# Altium schematic sheet 00_POWER.SchDoc(00_POWER)
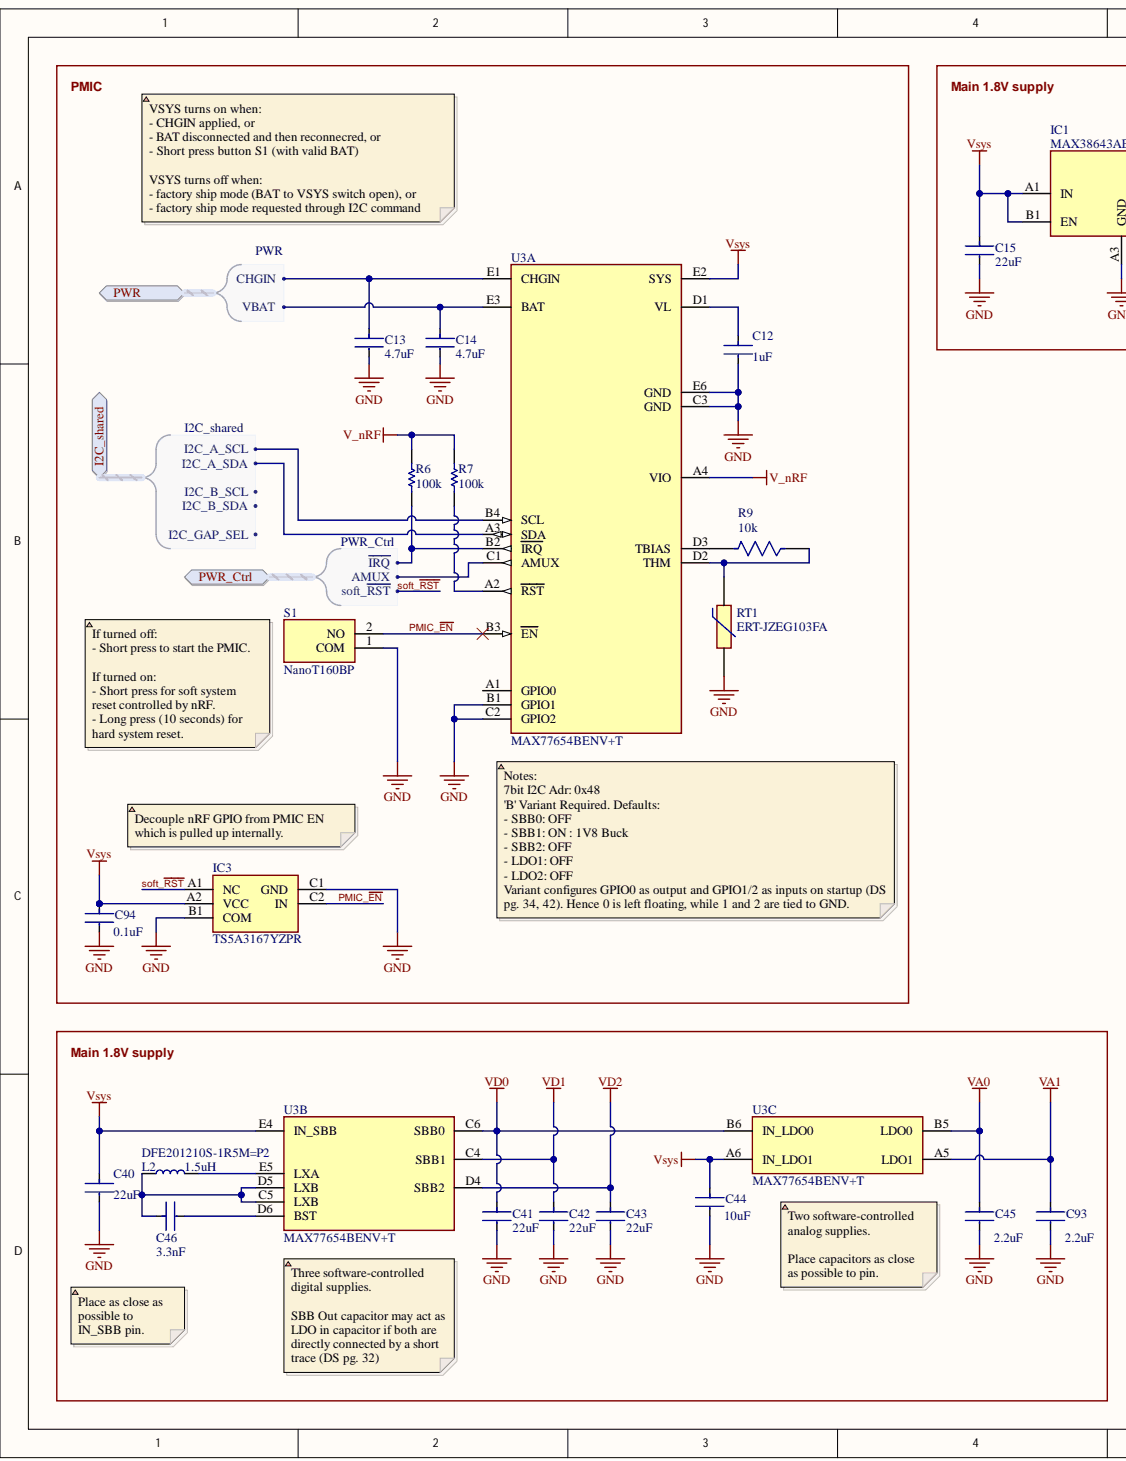
[diagram p0: 00_POWER.SchDoc, left]
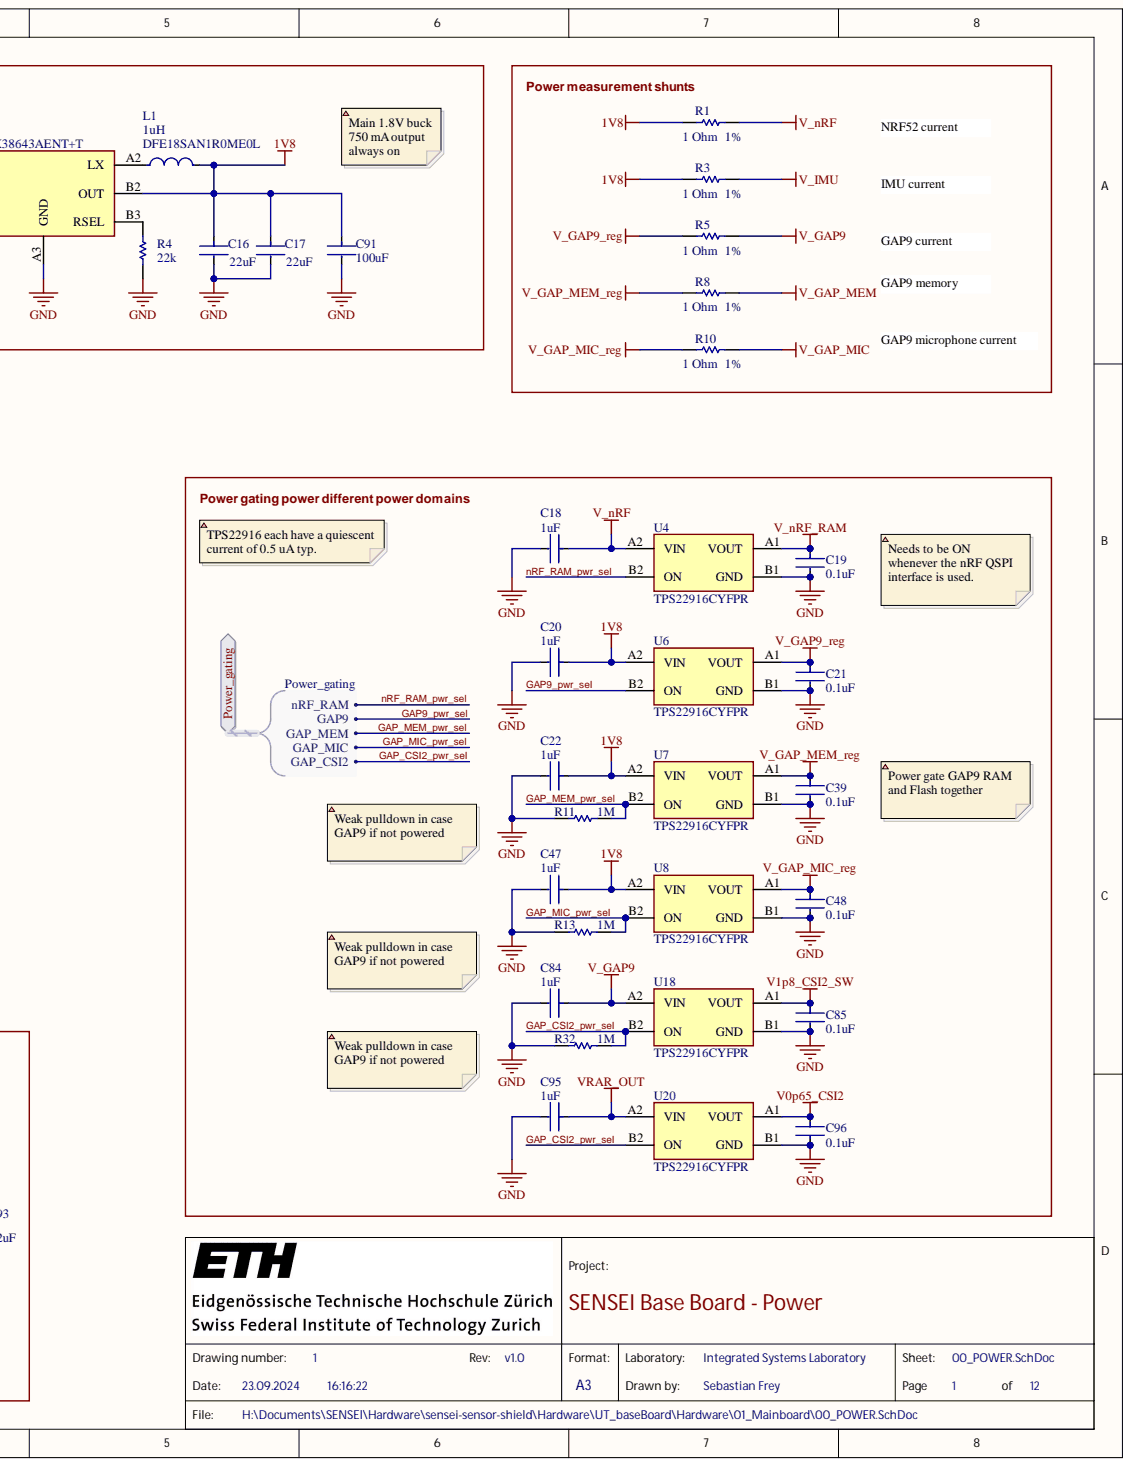
[diagram p1: 00_POWER.SchDoc, right]

## Parts
C12  Capacitance: 1uF  [FP-CL03-IPC_B]  (hidden comment: CL03A105KP3NSNC)
C13  Capacitance: 4.7uF  [FP-C1005-050-0_15-IPC_C]  (hidden comment: C1005X5R0J475K050BC)
C14  Capacitance: 4.7uF  [FP-C1005-050-0_15-IPC_C]  (hidden comment: C1005X5R0J475K050BC)
C15  Capacitance: 22uF  [FP-CL746-IPC_C]  (hidden comment: CL05A226MQ5QUNC)
C16  Capacitance: 22uF  [FP-CL746-IPC_C]  (hidden comment: CL05A226MQ5QUNC)
C17  Capacitance: 22uF  [FP-CL746-IPC_C]  (hidden comment: CL05A226MQ5QUNC)
C18  Capacitance: 1uF  [FP-CL03-IPC_B]  (hidden comment: CL03A105KP3NSNC)
C19  Capacitance: 0.1uF  [FP-GRM033-0_03-IPC_B]  (hidden comment: GRM033Z71C104KE14D)
C20  Capacitance: 1uF  [FP-CL03-IPC_B]  (hidden comment: CL03A105KP3NSNC)
C21  Capacitance: 0.1uF  [FP-GRM033-0_03-IPC_B]  (hidden comment: GRM033Z71C104KE14D)
C22  Capacitance: 1uF  [FP-CL03-IPC_B]  (hidden comment: CL03A105KP3NSNC)
C39  Capacitance: 0.1uF  [FP-GRM033-0_03-IPC_B]  (hidden comment: GRM033Z71C104KE14D)
C40  Capacitance: 22uF  [FP-CL746-IPC_C]  (hidden comment: CL05A226MQ5QUNC)
C41  Capacitance: 22uF  [FP-CL746-IPC_C]  (hidden comment: CL05A226MQ5QUNC)
C42  Capacitance: 22uF  [FP-CL746-IPC_C]  (hidden comment: CL05A226MQ5QUNC)
C43  Capacitance: 22uF  [FP-CL746-IPC_C]  (hidden comment: CL05A226MQ5QUNC)
C44  value 10uF  Capacitance: 10uF  [FP-CL05A106MP5NUNC-IPC_C]  (hidden comment: CL05A106MP5NUNC)
C45  Capacitance: 2.2uF  [FP-GRM155-0_2-IPC_C]  (hidden comment: GRM155C70J225KE11D)
C46  Capacitance: 3.3nF  [FP-GRM033-0_03-IPC_A]  (hidden comment: GRM033R71E332KA12D)
C47  Capacitance: 1uF  [FP-CL03-IPC_B]  (hidden comment: CL03A105KP3NSNC)
C48  Capacitance: 0.1uF  [FP-GRM033-0_03-IPC_B]  (hidden comment: GRM033Z71C104KE14D)
C84  Capacitance: 1uF  [FP-CL03-IPC_B]  (hidden comment: CL03A105KP3NSNC)
C85  Capacitance: 0.1uF  [FP-GRM033-0_03-IPC_B]  (hidden comment: GRM033Z71C104KE14D)
C91  Capacitance: 100uF  [FP-GRM21B-0_2-e0_2_0_7-MFG]  (hidden comment: GRM21BR60G107ME11L)
C93  Capacitance: 2.2uF  [FP-GRM155-0_2-IPC_C]  (hidden comment: GRM155C70J225KE11D)
C94  Capacitance: 0.1uF  [FP-GRM033-0_03-IPC_B]  (hidden comment: GRM033Z71C104KE14D)
C95  Capacitance: 1uF  [FP-CL03-IPC_B]  (hidden comment: CL03A105KP3NSNC)
C96  Capacitance: 0.1uF  [FP-GRM033-0_03-IPC_B]  (hidden comment: GRM033Z71C104KE14D)
IC1  MAX38643AENT+T  [BGA6C40P3X2_142X89X50]
IC3  TS5A3167YZPR  [BGA5C50P2X3_89X139X50]
L1  DFE18SAN1R0ME0L  Inductance: 1uH  [FP-DFE18SAN_E0-MFG]
L2  DFE201210S-1R5M=P2  Inductance: 1.5uH  [IND_0805_DFE201210S]
R1  value 1 Ohm  Tolerance: 1%  [RESC1005X40X25LL05T10]  (hidden comment: CRCW04021R00FKEDHP)
R3  value 1 Ohm  Tolerance: 1%  [RESC1005X40X25LL05T10]  (hidden comment: CRCW04021R00FKEDHP)
R4  Resistance: 22k  [RESC0603X26X15LL03T05]  (hidden comment: RK73H1HTTC2202F)
R5  value 1 Ohm  Tolerance: 1%  [RESC1005X40X25LL05T10]  (hidden comment: CRCW04021R00FKEDHP)
R6  value 100k  [RESC0201(0603)_L]  (hidden comment: RC0201FR-07100KL)
R7  value 100k  [RESC0201(0603)_L]  (hidden comment: RC0201FR-07100KL)
R8  value 1 Ohm  Tolerance: 1%  [RESC1005X40X25LL05T10]  (hidden comment: CRCW04021R00FKEDHP)
R9  Resistance: 10k  [FP-RMCF0201-IPC_C]  (hidden comment: RMCF0201FT10K0)
R10  value 1 Ohm  Tolerance: 1%  [RESC1005X40X25LL05T10]  (hidden comment: CRCW04021R00FKEDHP)
R11  value 1M  [RESC0201(0603)_L]  (hidden comment: ERJ-1GNJ105C)
R13  value 1M  [RESC0201(0603)_L]  (hidden comment: ERJ-1GNJ105C)
R32  value 1M  [RESC0201(0603)_L]  (hidden comment: ERJ-1GNJ105C)
RT1  ERT-JZEG103FA  [ERTJZEG103FA]
S1  NanoT160BP  [NanoT160BP]
U3  MAX77654BENV+T  [WLP_30_MAX77654]  (parts A,B,C)
U4  TPS22916CYFPR  [BGA4C40P2X2_78X78X50]
U6  TPS22916CYFPR  [BGA4C40P2X2_78X78X50]
U7  TPS22916CYFPR  [BGA4C40P2X2_78X78X50]
U8  TPS22916CYFPR  [BGA4C40P2X2_78X78X50]
U18  TPS22916CYFPR  [BGA4C40P2X2_78X78X50]
U20  TPS22916CYFPR  [BGA4C40P2X2_78X78X50]

## Ports
I2C_shared, I2C_shared.I2C_A_SCL, I2C_shared.I2C_A_SDA, I2C_shared.I2C_B_SCL, I2C_shared.I2C_B_SDA, I2C_shared.I2C_GAP_SEL, Power_gating, Power_gating.GAP9, Power_gating.GAP_CSI2, Power_gating.GAP_MEM, Power_gating.GAP_MIC, Power_gating.nRF_RAM, PWR, PWR.CHGIN, PWR.VBAT, PWR_Ctrl, PWR_Ctrl.AMUX, PWR_Ctrl.soft_~{RST}, PWR_Ctrl.~{IRQ}

## Nets
1V8: C16.1 C17.1 C20.1 C22.1 C47.1 C91.1 IC1.B2(OUT) L1.2 R1.1 R3.1 U6.A2(VIN) U7.A2(VIN) U8.A2(VIN)
GND: C12.2 C13.2 C14.2 C15.2 C16.2 C17.2 C18.2 C19.2 C20.2 C21.2 C22.2 C39.2 C40.2 C41.2 C42.2 C43.2 C44.2 C45.2 C47.2 C48.2 C84.2 C85.2 C91.2 C93.2 C94.2 C95.2 C96.2 IC1.A3(GND) IC3.B1(COM) IC3.C1(GND) R4.1 R11.1 R13.1 R32.1 RT1.2 S1.1 U3.B1(GPIO1) U3.C2(GPIO2) U3.C3(GND) U3.E6(GND) U4.B1(GND) U6.B1(GND) U7.B1(GND) U8.B1(GND) U18.B1(GND) U20.B1(GND)
V0p65_CSI2: C96.1 U20.A1(VOUT)
V1p8_CSI2_SW: C85.1 U18.A1(VOUT)
V_GAP9: C84.1 R5.2 U18.A2(VIN)
V_GAP9_reg: C21.1 R5.1 U6.A1(VOUT)
V_GAP_MEM: R8.2
V_GAP_MEM_reg: C39.1 R8.1 U7.A1(VOUT)
V_GAP_MIC: R10.2
V_GAP_MIC_reg: C48.1 R10.1 U8.A1(VOUT)
V_IMU: R3.2
V_nRF: C18.1 R1.2 R6.2 R7.2 U3.A4(VIO) U4.A2(VIN)
V_nRF_RAM: C19.1 U4.A1(VOUT)
VA0: C45.1 U3.B5(LDO0)
VA1: C93.1 U3.A5(LDO1)
VD0: C41.1 U3.B6(IN_LDO0) U3.C6(SBB0)
VD1: C42.1 U3.C4(SBB1)
VD2: C43.1 U3.D4(SBB2)
VRAR_OUT: C95.1 U20.A2(VIN)
Vsys: C15.1 C40.1 C44.1 C94.1 IC1.A1(IN) IC1.B1(EN) IC3.A2(VCC) U3.A6(IN_LDO1) U3.E2(SYS) U3.E4(IN_SBB)
GAP9_pwr_sel: U6.B2(ON) ; port Power_gating
GAP_CSI2_pwr_sel: R32.2 U18.B2(ON) U20.B2(ON) ; port Power_gating
GAP_MEM_pwr_sel: R11.2 U7.B2(ON) ; port Power_gating
GAP_MIC_pwr_sel: R13.2 U8.B2(ON) ; port Power_gating
nRF_RAM_pwr_sel: U4.B2(ON) ; port Power_gating
PMIC_~{EN}: IC3.C2(IN) S1.2 U3.B3(EN)
soft_~{RST}: IC3.A1(NC) ; port PWR_Ctrl
NetC12_1: C12.1 U3.D1(VL)
NetC13_1: C13.1 U3.E1(CHGIN) ; port PWR
NetC14_1: C14.1 U3.E3(BAT) ; port PWR
NetC46_1: C46.1 L2.1 U3.C5(LXB) U3.D5(LXB)
NetC46_2: C46.2 U3.D6(BST)
NetIC1_A2: IC1.A2(LX) L1.1
NetIC1_B3: IC1.B3(RSEL) R4.2
NetL2_2: L2.2 U3.E5(LXA)
NetR6_1: R6.1 U3.B2(IRQ) ; port PWR_Ctrl
NetR7_1: R7.1 U3.A2(RST)
NetR9_1: R9.1 RT1.1 U3.D2(THM)
NetR9_2: R9.2 U3.D3(TBIAS)
NetU3_A3: U3.A3(SDA) ; port I2C_shared
NetU3_B4: U3.B4(SCL) ; port I2C_shared
NetU3_C1: U3.C1(AMUX) ; port PWR_Ctrl
N00001: ; port I2C_shared
N00003: ; port I2C_shared
N00005: ; port I2C_shared

Not connected: U3 A1(GPIO0)

## Library data of the parts
C12 C18 C20 C22 C47 C84 C95 = CMP-13271-003594-1: Cap Ceramic 1uF 10V X5R ±10% Pad SMD 0201 +85°C T/R; Case Code (Imperial): 0201; Case Code (Metric): 0603; Case/Package: 0201; Component Kind: Standard; Length: 0.024inch; Library Name: Altium Content Vault; Max Operating Temperature: 85°C; Min Operating Temperature: -55°C; Mount: Surface Mount; Packaging: Cut Tape; Thickness: 0.013inch; Tolerance: 10%; Voltage Rating: 10V; Width: 0.012inch
C13 C14 = CMP-2000-05437-2: CAP CER 4.7UF 6.3V X5R 0402; Dielectric Material: Ceramic; Tolerance: 10%; Case/Package: 0402; Component Kind: Standard; Depth: 0.5mm; Dielectric: X5R; Height: 0.5mm; Lead Free: Lead Free; Length: 1mm; Library Name: Altium Content Vault; Max Operating Temperature: 85°C; Min Operating Temperature: -55°C; Mount: Surface Mount; RoHS Compliant: Yes; Series: C; Termination: SMD/SMT; Voltage: 6.3V
C15-C17 C40-C43 = CMP-13271-000286-1: Cap Ceramic 22uF 6.3V X5R ±20% SMD 0402 +85°C Paper T/R; Case Code (Imperial): 0402; Case Code (Metric): 1005; Case/Package: 0402; Component Kind: Standard; Length: 0.039inch; Library Name: Altium Content Vault; Max Operating Temperature: 85°C; Min Operating Temperature: -55°C; Mount: Surface Mount; Packaging: Tape and Reel; Thickness: 0.022inch; Tolerance: 20%; Voltage Rating: 6.3V; Width: 0.02inch
C19 C21 C39 C48 C85 C94 C96 = CMP-06035-035508-1: Chip Multilayer Ceramic Capacitors for General Purpose, 0201, 0.10uF, X7R, 15%, 10%, 16V; Case/Package: 0201; Component Kind: Standard; Library Name: Altium Content Vault
C44 = CMP-13271-000002-1: CAP CER 10UF 10V X5R 0402; Manufacturer: Samsung; Material: Ceramic; Temperature Coefficient: 15%; Tolerance: 20%; Automotive: No; Category: Cap; Component Kind: Standard; ComponentLink2Description: Datasheet; ComponentLink2URL: http://www.samsungsem.com/kr/support/product-search/mlcc/__icsFiles/afieldfile/2019/05/10/19-CL05A106MP5NUNC.pdf; Device Class L1: Passive Components; Device Class L2: Capacitors; Device Class L3: Ceramic Capacitors; Digikey Description: CAP CER 10UF 10V X5R 0402; Height: 0.7mm; Ipc Land Pattern Name: CAPC10050X50; Lead Free: Yes; Library Name: Altium Content Vault; Manufacturer Part Number: CL05A106MP5NUNC; Octopart: https://octopart.com/cl05a106mp5nunc-samsung-22457395; Package: CL05A106MP5NUNC; RoHS: TRUE; Temperature: -55°C to +85°C; Temperature Characteristic: X5R; Temperature Range High: +85°C; Temperature Range Low: -55°C; Voltage Rating: 10V
C45 C93 = CMP-06035-014902-1: Chip Multilayer Ceramic Capacitors for General Purpose, 0402, 2.2uF, X7S, 22%, 10%, 6.3V; Case Code (Imperial): 0402; Case Code (Metric): 1005; Case/Package: 0402; Component Kind: Standard; Dielectric: X7S; Height: 0.5mm; Length: 0.039inch; Library Name: Altium Content Vault; Max Operating Temperature: 125°C; Min Operating Temperature: -55°C; Mount: Surface Mount; Package Quantity: 10000; Packaging: Tape and Reel; Resistor Type: Ceramic; Termination: SMD/SMT; Thickness: 0.022inch; Tolerance: 10%; Voltage Rating: 6.3V; Voltage Rating (DC): 6.3V; Weight: 0.000212oz; Width: 0.02inch
C46 = CMP-06035-035563-1: Chip Multilayer Ceramic Capacitors for General Purpose, 0201, 3300pF, X7R, 15%, 10%, 25V; Case Code (Imperial): 0201; Case Code (Metric): 0603; Case/Package: 0201; Component Kind: Standard; Dielectric: X7R; Height: 0.3mm; Length: 0.024inch; Library Name: Altium Content Vault; Max Operating Temperature: 125°C; Min Operating Temperature: -55°C; Mount: Surface Mount; Package Quantity: 15000; Packaging: Tape and Reel; Resistor Type: Ceramic; Termination: SMD/SMT; Thickness: 0.013inch; Tolerance: 10%; Voltage Rating: 25V; Voltage Rating (DC): 25V; Weight: 1.2E-05oz; Width: 0.012inch
C91 = CMP-06035-033356-1: Chip Multilayer Ceramic Capacitors for General Purpose, 0805, 100uF, X5R, 15%, 20%, 4V; Case Code (Imperial): 0805; Case Code (Metric): 2012; Case/Package: 0805; Component Kind: Standard; Dielectric: X5R; Length: 0.079inch; Library Name: Altium Content Vault; Max Operating Temperature: 85°C; Min Operating Temperature: -55°C; Mount: Surface Mount; Packaging: Tape and Reel; Thickness: 0.057inch; Tolerance: 20%; Voltage Rating: 4V; Voltage Rating (DC): 4V; Width: 0.049inch
IC1 = MAX38643AENT+: Integrated Circuit; Manufacturer_Name: Analog Devices; Component Kind: Standard; Datasheet Link: https://www.mouser.com/datasheet/2/256/MAX38640A-MAX38643B-1516623.pdf; Height: 0.5mm; Library Name: SamacSys.SchLib; Manufacturer_Part_Number: MAX38643AENT+; Mouser Part Number: 700-MAX38643AENT+; Mouser Price/Stock: https://www.mouser.co.uk/ProductDetail/Maxim-Integrated/MAX38643AENT%2b?qs=PzGy0jfpSMssjCGgbzOt4w%3D%3D
IC3 = TS5A3167YZPR: Integrated Circuit; Manufacturer_Name: Texas Instruments; Arrow Part Number: TS5A3167YZPR; Arrow Price/Stock: https://www.arrow.com/en/products/ts5a3167yzpr/texas-instruments; Component Kind: Standard; Datasheet Link: http://www.ti.com/lit/gpn/ts5a3167; Height: 0.5mm; Manufacturer_Part_Number: TS5A3167YZPR; Mouser Part Number: 595-TS5A3167YZPR; Mouser Price/Stock: https://www.mouser.co.uk/ProductDetail/Texas-Instruments/TS5A3167YZPR/?qs=0O%2FZFlpUpJV2gM0iE3NeCQ%3D%3D
L1 = CMP-06042-016331-1: FIXED IND 1UH 1.6A 144 MOHM SMD; Core Material: Metal; DC Resistance (DCR): 144mR; Saturation Current: 2A; Shielding: Shielded; Test Frequency: 1MHz; Tolerance: 20%; Case Code (Imperial): 0603; Case Code (Metric): 1608; Case/Package: 0603; Component Kind: Standard; Current Rating: 1.6A; Library Name: Altium Content Vault; Max Operating Temperature: 85°C; Min Operating Temperature: -40°C; Mount: Surface Mount; Packaging: Cut Tape; RoHS Compliant: Yes
L2 = L_0805_1.5uH_2A: L 1.5uH 2A 0805; Manufacturer: Murata; Component Kind: Standard; Library Name: EEG_SchLib.SchLib; Manufacturer Part Number: DFE201210S-1R5M=P2; Supplier 1: Mouser; Supplier Part Number 1: 81-DFE201210S-1R5MP2
R1 R3 R5 R8 R10 = CMP-2002-03047-1: Manufacturer: Vishay Dale; Component Kind: Standard; Library Name: Altium Content Vault; Part Number: CRCW04021R00FKEDHP
R4 = CMP-2004-01604-1: Manufacturer: KOA Speer; Component Kind: Standard; Library Name: Altium Content Vault; Part Number: RK73H1HTTC2202F
R6 R7 = CMP-1010-00642-1: 100K 0.05W 1% 0201 (0603 Metric)  SMD; Case-EIA: 0201; Case-Metric: 0603; Component Kind: Standard; Library Name: Altium Content Vault; Power: 0.05 W; Technology: SMT; Tolerance: 1 %
R9 = CMP-26527-002755-1: RES 10K OHM 1% 1/20W 0201; Max Power Dissipation: 50mW; Temperature Coefficient: 200ppm/°C; Case Code (Imperial): 0201; Case Code (Metric): 0603; Case/Package: 0201; Component Kind: Standard; Contact Plating: Tin; Height: 0.009inch; Length: 0.024inch; Library Name: Altium Content Vault; Max Operating Temperature: 125°C; Min Operating Temperature: -55°C; Mount: Surface Mount; Number of Terminations: 2; Packaging: Tape and Reel; Power Rating: 50mW; Resistor Type: Thick Film; RoHS Compliant: Yes; Series: RMCF; Tolerance: 1%; Width: 0.012inch
R11 R13 R32 = CMP-1010-00146-1: 1M 0.05W 5% 0201 (0603 Metric)  SMD; Case-EIA: 0201; Case-Metric: 0603; Component Kind: Standard; Library Name: Altium Content Vault; Power: 0.05 W; Technology: SMT; Tolerance: 5 %
RT1 = ERT-JZEG103FA: Thermistor; Manufacturer_Name: Panasonic; Arrow Part Number: ERT-JZEG103FA; Arrow Price/Stock: https://www.arrow.com/en/products/ert-jzeg103fa/panasonic?region=nac; Component Kind: Standard; Datasheet Link: https://industrial.panasonic.com/cdbs/www-data/pdf/AUA0000/AUA0000C8.pdf; Height: 0.33mm; Manufacturer_Part_Number: ERT-JZEG103FA; Mouser Part Number: 667-ERT-JZEG103FA; Mouser Price/Stock: https://www.mouser.co.uk/ProductDetail/Panasonic/ERT-JZEG103FA?qs=8rMlxy%252BA7jnazXT5AW8efg%3D%3D
S1 = NanoT160BP: Switch; Manufacturer_Name: LITTELFUSE; Component Kind: Standard; Datasheet Link: https://www.ckswitches.com/media/2866/nanot.pdf; Height: 1mm; Manufacturer_Part_Number: NanoT160BP
U3 = MAX77654BENV+T: Buck-Boost Converter With LDO Regulator 5-OUT 30-Pin WLP T/R; Manufacturer: Maxim; Component Kind: Standard; Library Name: EEG_SchLib.SchLib; Manufacturer Part Number: MAX77654BENV+T; Supplier 1: Mouser; Supplier Part Number 1: 700-MAX77654BENV+T
U4 U6-U8 U18 U20 = TPS22916CYFPR: Integrated Circuit; Manufacturer_Name: Texas Instruments; Arrow Part Number: TPS22916CYFPR; Arrow Price/Stock: https://www.arrow.com/en/products/tps22916cyfpr/texas-instruments?region=nac; Component Kind: Standard; Datasheet Link: http://www.ti.com/lit/gpn/tps22916; Height: 0.5mm; Library Name: SamacSys.SchLib; Manufacturer_Part_Number: TPS22916CYFPR; Mouser Part Number: 595-TPS22916CYFPR; Mouser Price/Stock: https://www.mouser.co.uk/ProductDetail/Texas-Instruments/TPS22916CYFPR?qs=EU6FO9ffTweddKR4NsfVQg%3D%3D
